# Altium schematic sheet Buck.SchDoc
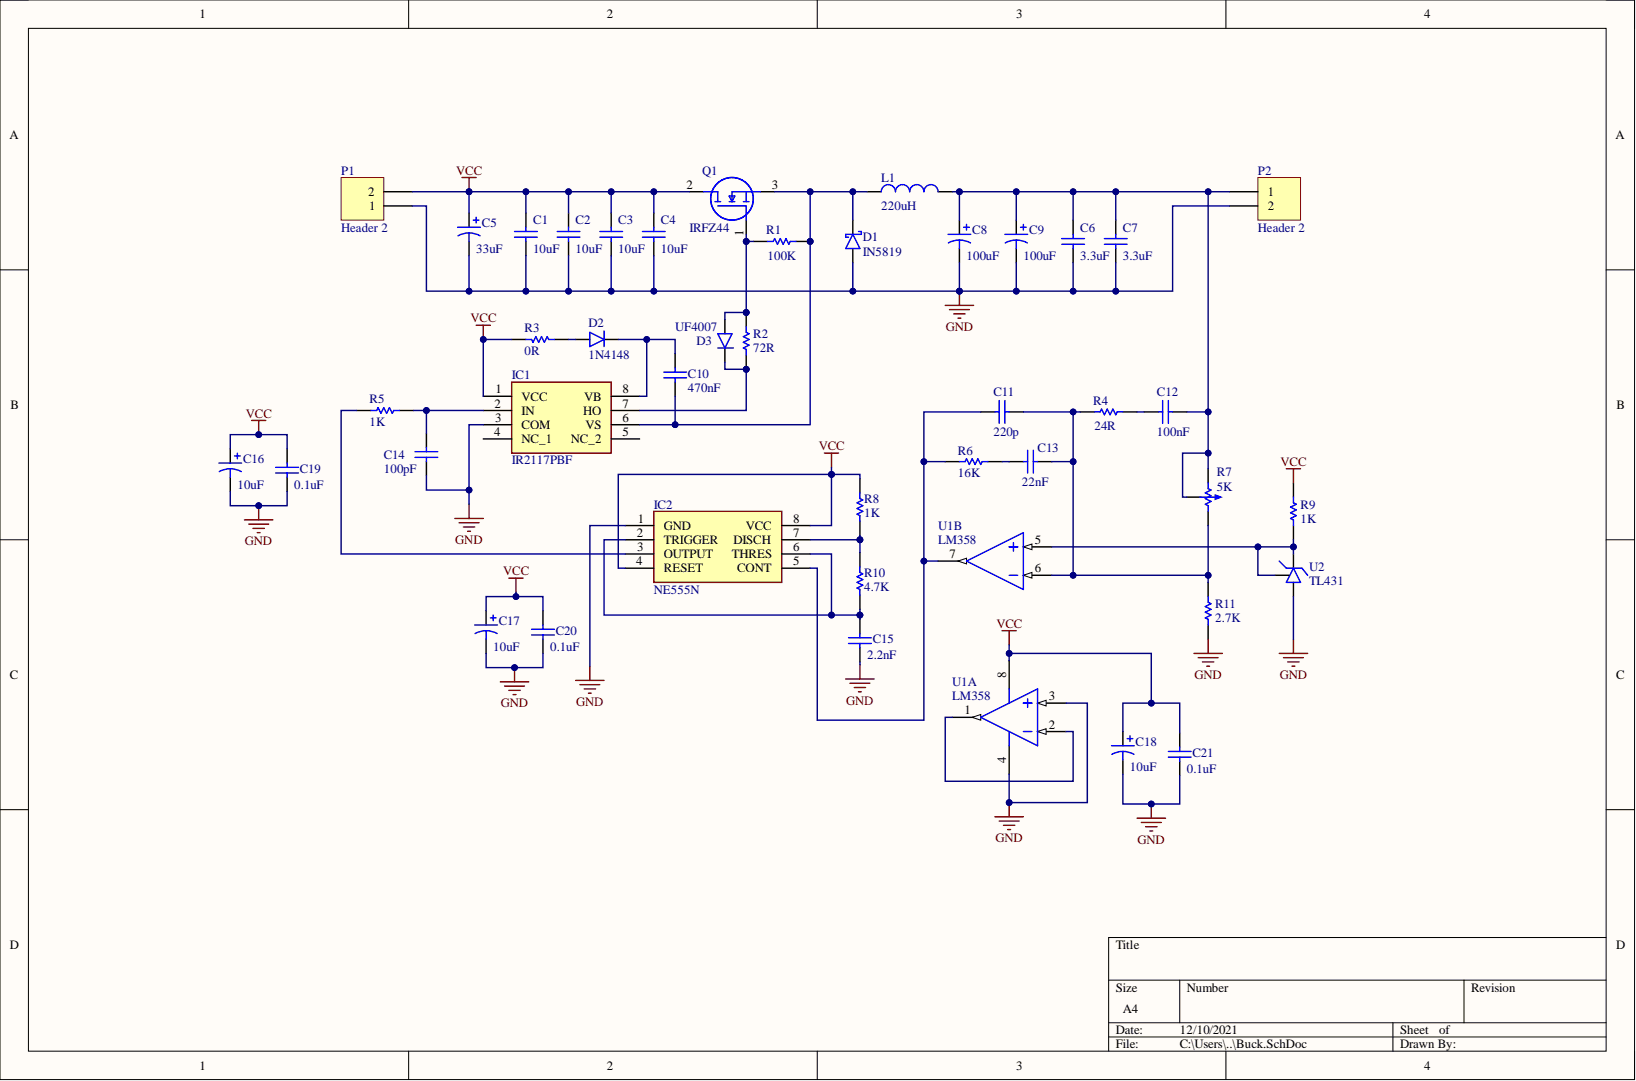
[diagram p0: Buck.SchDoc]

## Parts
C1  value 10uF  [CAP_MLCC_TH]  (hidden comment: Cap)
C2  value 10uF  [CAP_MLCC_TH]  (hidden comment: Cap)
C3  value 10uF  [CAP_MLCC_TH]  (hidden comment: Cap)
C4  value 10uF  [CAP_MLCC_TH]  (hidden comment: Cap)
C5  value 33uF  [CAP_6.3x11]  (hidden comment: Cap Pol1)
C6  value 3.3uF  [CAP_MLCC_TH]  (hidden comment: Cap)
C7  value 3.3uF  [CAP_MLCC_TH]  (hidden comment: Cap)
C8  value 100uF  [CAP_6.3x11]  (hidden comment: Cap Pol1)
C9  value 100uF  [CAP_6.3x11]  (hidden comment: Cap Pol1)
C10  value 470nF  [CAP_FILM_5MM]  (hidden comment: Cap)
C11  value 220p  [CAP_FILM_5MM]  (hidden comment: Cap)
C12  value 100nF  [CAP_FILM_5MM]  (hidden comment: Cap)
C13  value 22nF  [CAP_FILM_5MM]  (hidden comment: Cap)
C14  value 100pF  [CAP_FILM_5MM]  (hidden comment: Cap)
C15  value 2.2nF  [CAP_FILM_5MM]  (hidden comment: Cap)
C16  value 10uF  [CAP_5x12]  (hidden comment: Cap Pol1)
C17  value 10uF  [CAP_5x12]  (hidden comment: Cap Pol1)
C18  value 10uF  [CAP_5x12]  (hidden comment: Cap Pol1)
C19  value 0.1uF  [CAP_FILM_5MM]  (hidden comment: Cap)
C20  value 0.1uF  [CAP_FILM_5MM]  (hidden comment: Cap)
C21  value 0.1uF  [CAP_FILM_5MM]  (hidden comment: Cap)
D1  IN5819  [DIOAD1413W86L463D238]
D2  1N4148  [DIODE-TH]
D3  UF4007  [DIODE-TH]
IC1  IR2117PBF  [DIP8]
IC2  NE555N  [DIP8]
L1  value 220uH  [TOROIDAL_INDUCTOR]  (hidden comment: Inductor)
P1  Header 2  [CONECTOR_BORNE_2P]
P2  Header 2  [CONECTOR_BORNE_2P]
Q1  IRFZ44  [IRF2804STRLPBF]
R1  value 100K  [AXIAL-RES]  (hidden comment: Res1)
R2  value 72R  [AXIAL-RES]  (hidden comment: Res1)
R3  value 0R  [AXIAL-RES]  (hidden comment: Res1)
R4  value 24R  [AXIAL-RES]  (hidden comment: Res1)
R5  value 1K  [AXIAL-RES]  (hidden comment: Res1)
R6  value 16K  [AXIAL-RES]  (hidden comment: Res1)
R7  value 5K  [VAR_RES]  (hidden comment: Potentiometer)
R8  value 1K  [AXIAL-RES]  (hidden comment: Res1)
R9  value 1K  [AXIAL-RES]  (hidden comment: Res1)
R10  value 4.7K  [AXIAL-RES]  (hidden comment: Res1)
R11  value 2.7K  [AXIAL-RES]  (hidden comment: Res1)
U1  LM358  [DIP8]  (parts A,B)
U2  TL431  [TO127P419X482X1041-3P]

## Nets
GND: C1.2 C2.2 C3.2 C4.2 C5.2 C6.2 C7.2 C8.2 C9.2 C14.2 C15.1 C16.2 C17.2 C18.2 C19.1 C20.1 C21.1 D1.2 IC1.3(COM) IC2.1(GND) P1.1 P2.2 R11.1 U1.3 U1.4 U2.2
VCC: C1.1 C2.1 C3.1 C4.1 C5.1 C16.1 C17.1 C18.1 C19.2 C20.2 C21.2 IC1.1(VCC) IC2.4(RESET) IC2.8(VCC) P1.2 Q1.2 R3.1 R8.1 R9.2 U1.8
NetC6_1: C6.1 C7.1 C8.1 C9.1 C12.2 L1.2 P2.1 R7.1 R7.2
NetC10_1: C10.1 D2.1 IC1.8(VB)
NetC10_2: C10.2 D1.1 IC1.6(VS) L1.1 Q1.3 R1.1
NetC11_1: C11.1 IC2.5(CONT) R6.2 U1.7
NetC11_2: C11.2 C13.2 R4.2 R7.3 R11.2 U1.6
NetC12_1: C12.1 R4.1
NetC13_1: C13.1 R6.1
NetC14_1: C14.1 IC1.2(IN) R5.1
NetC15_2: C15.2 IC2.2(TRIGGER) IC2.6(THRES) R10.2
NetD2_2: D2.2 R3.2
NetD3_1: D3.1 IC1.7(HO) R2.1
NetD3_2: D3.2 Q1.1 R1.2 R2.2
NetIC2_3: IC2.3(OUTPUT) R5.2
NetIC2_7: IC2.7(DISCH) R8.2 R10.1
NetR9_1: R9.1 U1.5 U2.1 U2.3
NetU1_1: U1.1 U1.2

Not connected: IC1 4(NC_1) 5(NC_2)

## Library data of the parts
C1-C4 C6 C7 C10-C15 C19-C21 = Cap: Capacitor; Component Kind: Standard; LatestRevisionDate: 17-Jul-2002; LatestRevisionNote: Re-released for DXP Platform.; Library Name: Miscellaneous Devices.IntLib; PackageDescription: Radial Cap, Thru-Hole; 2 Leads; 0.3 in Pin Spacing; PackageReference: RAD-0.3; Published: 8-Jun-2000; Signal Integrity: Cap; Simulation: CAP; Publisher: Altium Limited
C5 C8 C9 C16-C18 = Cap Pol1: Polarized Capacitor (Radial); Component Kind: Standard; LatestRevisionDate: 17-Jul-2002; LatestRevisionNote: Re-released for DXP Platform.; Library Name: Miscellaneous Devices.IntLib; PackageDescription: Polarized Capacitor (Radial); 2 Leads; PackageReference: RB7.6-15; Published: 8-Jun-2000; Signal Integrity: Cap Pol; Simulation: CAP; Publisher: Altium Limited
D1 = Schottky: Diode; Manufacturer_Name: ON Semiconductor; Manufacturer_Part_Number: UF4007; Arrow Part Number: UF4007; Arrow Price/Stock: https://www.arrow.com/en/products/uf4007/on-semiconductor; Component Kind: Standard; Datasheet Link: http://www.onsemi.com/pub/Collateral/UF4007-D.pdf; Library Name: Schlib.SchLib; Mouser Part Number: 512-UF4007; Mouser Price/Stock: https://www.mouser.co.uk/ProductDetail/ON-Semiconductor-Fairchild/UF4007?qs=NwIfwa72FlplAHiXupLMBA%3D%3D
D2 D3 = UF4007: Diode; Manufacturer_Name: ON Semiconductor; Arrow Part Number: UF4007; Arrow Price/Stock: https://www.arrow.com/en/products/uf4007/on-semiconductor; Component Kind: Standard; Datasheet Link: http://www.onsemi.com/pub/Collateral/UF4007-D.pdf; Library Name: Schlib.SchLib; Manufacturer_Part_Number: UF4007; Mouser Part Number: 512-UF4007; Mouser Price/Stock: https://www.mouser.co.uk/ProductDetail/ON-Semiconductor-Fairchild/UF4007?qs=NwIfwa72FlplAHiXupLMBA%3D%3D
IC1 = IR2117PBF: Integrated Circuit; Manufacturer_Name: Infineon; Arrow Part Number: IR2117PBF; Arrow Price/Stock: https://www.arrow.com/en/products/ir2117pbf/infineon-technologies-ag?region=nac; Component Kind: Standard; Datasheet Link: https://www.infineon.com/dgdl/ir2117.pdf?fileId=5546d462533600a4015355c84331168d; Height: 5.33mm; Library Name: Schlib.SchLib; Manufacturer_Part_Number: IR2117PBF; Mouser Part Number: 942-IR2117PBF; Mouser Price/Stock: https://www.mouser.co.uk/ProductDetail/Infineon-IR/IR2117PBF?qs=dQmlx5f5cRIDilT7sCxYHA%3D%3D
IC2 = NE555N: Integrated Circuit; Manufacturer_Name: HGSEMI; Component Kind: Standard; Datasheet Link: https://datasheet.lcsc.com/szlcsc/1906111919_HGSEMI-NE555N_C398063.pdf; Height: 5.33mm; Library Name: Schlib.SchLib; Manufacturer_Part_Number: NE555N
L1 = Inductor: Code_IEC: 1005; Code_JEITA: 0402; Component Kind: Standard; Description: Inductor; LatestRevisionDate: 29-May-2009; LatestRevisionNote: IPC-7351 Footprint Added.; Library Name: Miscellaneous Devices.IntLib; PackageDescription: Chip Inductor; PackageReference: 0402-A; Published: 8-Jun-2000; Signal Integrity: Inductor; Simulation: INDUCTOR; Publisher: Altium Limited
P1 P2 = Header 2: Header, 2-Pin; Component Kind: Standard; LatestRevisionDate: 17-Jul-2002; LatestRevisionNote: Re-released for DXP Platform.; Library Name: Miscellaneous Connectors.IntLib; Signal Integrity: Connector; Publisher: Altium Limited
Q1 = IRFZ44NSPBF: MOSFET (N-Channel); Manufacturer_Name: Infineon; Arrow Part Number: IRFZ44NSPBF; Arrow Price/Stock: https://www.arrow.com/en/products/irfz44nspbf/infineon-technologies-ag; Component Kind: Standard; Datasheet Link: https://docs-emea.rs-online.com/webdocs/0791/0900766b807912db.pdf; Height: 4.83mm; Library Name: Schlib.SchLib; Manufacturer_Part_Number: IRFZ44NSPBF; Mouser Part Number: 942-IRFZ44NSPBF; Mouser Price/Stock: https://www.mouser.co.uk/ProductDetail/Infineon-IR/IRFZ44NSPBF?qs=nrWyqPbesnWSFHiezqzlbA%3D%3D
R1-R6 R8-R11 = Res1: Resistor; Component Kind: Standard; LatestRevisionDate: 17-Jul-2002; LatestRevisionNote: Re-released for DXP Platform.; Library Name: Miscellaneous Devices.IntLib; PackageDescription: Axial Device, Thru-Hole; 2 Leads; 0.3 in Pin Spacing; PackageReference: AXIAL-0.3; Published: 8-Jun-2000; Signal Integrity: Res; Simulation: RESISTOR; Publisher: Altium Limited
R7 = Potentiometer: Variable Resistor; Set Position: 0.5; Component Kind: Standard; LatestRevisionDate: 17-Jul-2002; LatestRevisionNote: Re-released for DXP Platform.; Library Name: Schlib.SchLib; PackageDescription: Axial Device, Thru-Hole; 2 Leads; 0.7 in Pin Spacing; PackageReference: AXIAL-0.7; Published: 8-Jun-2000; Signal Integrity: Res Adj; Simulation: VRES; Publisher: Altium Limited
U1 = LM358: FET Operational Amplifier; Component Kind: Standard; ComponentLink1Description: Manufacturer Link; ComponentLink1URL: http://www.analog.com/; DatasheetVersion: REV. B; LatestRevisionDate: 17-Jul-2002; LatestRevisionNote: Re-released for DXP Platform.; Library Name: Schlib.SchLib; PackageDescription: Can; 8 Leads; Pin Circle Dia. 5.08 mm; Lead Dia. 0.53 mm (max); PackageReference: H-08A; PackageVersion: 1990; Published: 8-Jun-2000; Simulation: AD645A; Publisher: Altium Limited
U2 = TL431: Diode; Manufacturer_Name: ON Semiconductor; Manufacturer_Part_Number: UF4007; Arrow Part Number: UF4007; Arrow Price/Stock: https://www.arrow.com/en/products/uf4007/on-semiconductor; Component Kind: Standard; Datasheet Link: http://www.onsemi.com/pub/Collateral/UF4007-D.pdf; Library Name: Schlib.SchLib; Mouser Part Number: 512-UF4007; Mouser Price/Stock: https://www.mouser.co.uk/ProductDetail/ON-Semiconductor-Fairchild/UF4007?qs=NwIfwa72FlplAHiXupLMBA%3D%3D
